# Altium schematic sheet MMB-2013-007a IO PS.SchDoc("MMB-2013-007a IO PS")
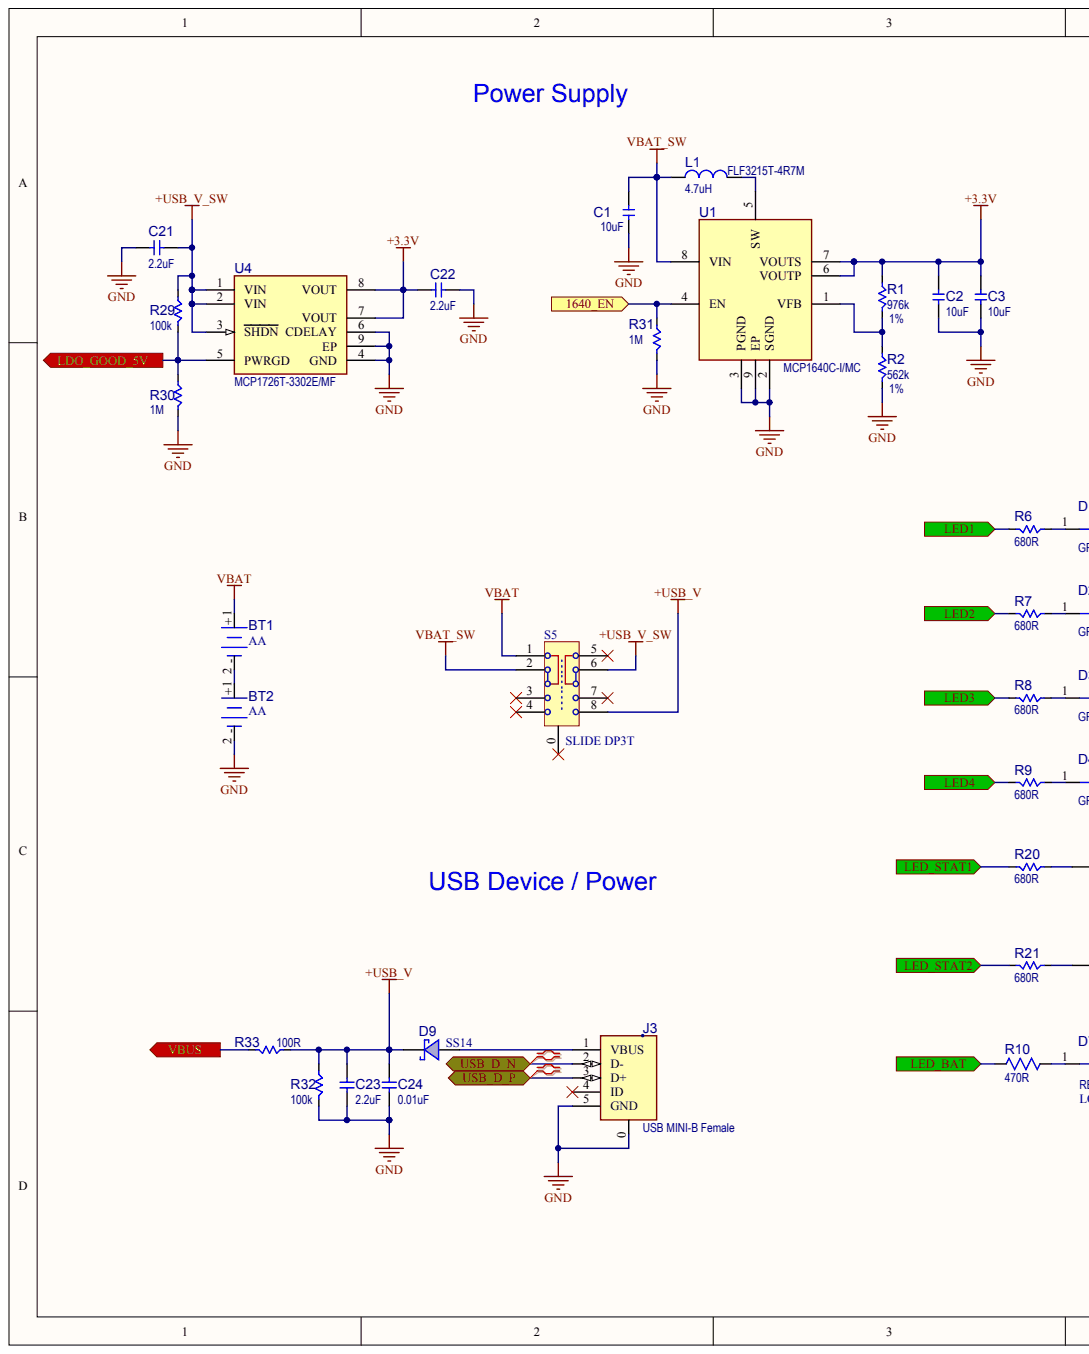
[diagram p0: MMB-2013-007a IO PS.SchDoc, left]
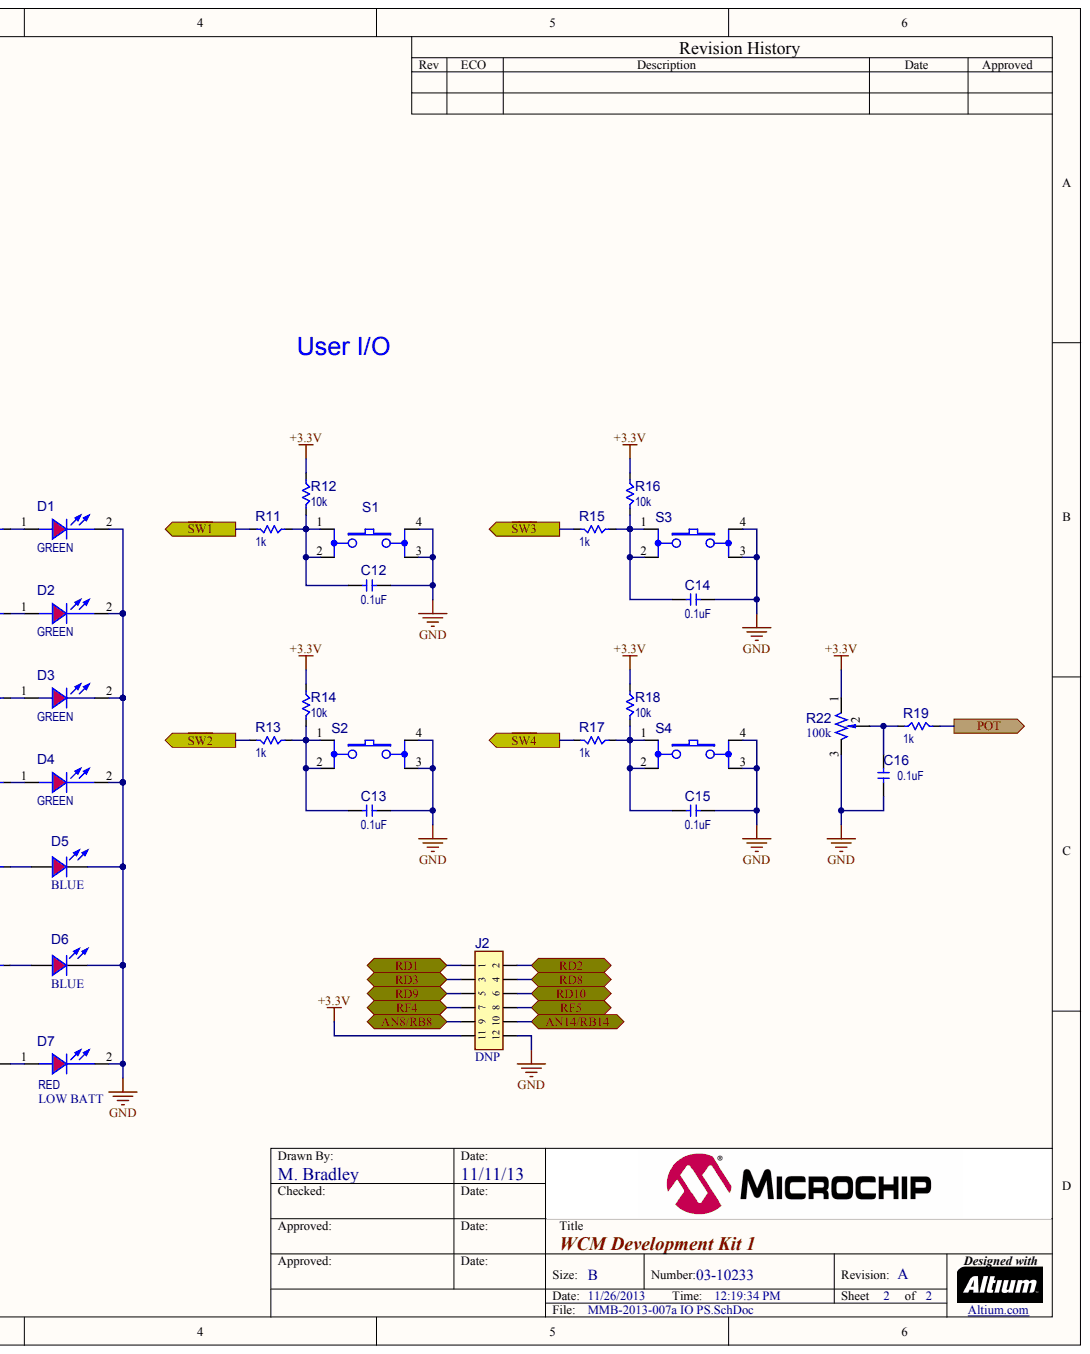
[diagram p1: MMB-2013-007a IO PS.SchDoc, right]

## Parts
BT1  value AA  (hidden comment: Battery 2 Pin)
BT2  value AA  (hidden comment: Battery 2 Pin)
C1  value 10uF  (hidden comment: Capacitor NP)
C2  value 10uF  (hidden comment: Capacitor NP)
C3  value 10uF  (hidden comment: Capacitor NP)
C12  value 0.1uF  (hidden comment: Capacitor NP)
C13  value 0.1uF  (hidden comment: Capacitor NP)
C14  value 0.1uF  (hidden comment: Capacitor NP)
C15  value 0.1uF  (hidden comment: Capacitor NP)
C16  value 0.1uF  (hidden comment: Capacitor NP)
C21  value 2.2uF  (hidden comment: Capacitor NP)
C22  value 2.2uF  (hidden comment: Capacitor NP)
C23  value 2.2uF  (hidden comment: Capacitor NP)
C24  value 0.01uF  (hidden comment: Capacitor NP)
D1  value GREEN  (hidden comment: LED)
D2  value GREEN  (hidden comment: LED)
D3  value GREEN  (hidden comment: LED)
D4  value GREEN  (hidden comment: LED)
D5  value BLUE  (hidden comment: LED)
D6  value BLUE  (hidden comment: LED)
D7  LOW BATT  value RED
D9  value SS14  (hidden comment: Diode Schottky)
J2  DNP
J3  value USB MINI-B Female  Type: USB  (hidden comment: CON USB-B)
L1  value 4.7uH  Manufacturer Part Number 1: FLF3215T-4R7M  (hidden comment: Inductor)
R1  value 976k  Tolerance: 1%  (hidden comment: Resistor)
R2  value 562k  Tolerance: 1%  (hidden comment: Resistor)
R6  value 680R  (hidden comment: Resistor)
R7  value 680R  (hidden comment: Resistor)
R8  value 680R  (hidden comment: Resistor)
R9  value 680R  (hidden comment: Resistor)
R10  value 470R  (hidden comment: Resistor)
R11  value 1k  (hidden comment: Resistor)
R12  value 10k  (hidden comment: Resistor)
R13  value 1k  (hidden comment: Resistor)
R14  value 10k  (hidden comment: Resistor)
R15  value 1k  (hidden comment: Resistor)
R16  value 10k  (hidden comment: Resistor)
R17  value 1k  (hidden comment: Resistor)
R18  value 10k  (hidden comment: Resistor)
R19  value 1k  (hidden comment: Resistor)
R20  value 680R  (hidden comment: Resistor)
R21  value 680R  (hidden comment: Resistor)
R22  value 100k  (hidden comment: Resistor Var)
R29  value 100k  (hidden comment: Resistor)
R30  value 1M  (hidden comment: Resistor)
R31  value 1M  (hidden comment: Resistor)
R32  value 100k  (hidden comment: Resistor)
R33  value 100R  (hidden comment: Resistor)
S1  Switch 4 Pin  (value not drawn)
S2  Switch 4 Pin  (value not drawn)
S3  Switch 4 Pin  (value not drawn)
S4  Switch 4 Pin  (value not drawn)
S5  value SLIDE DP3T  (hidden comment: Switch 8 Pin DP3T)
U1  Manufacturer Part Number 1: MCP1640C-I/MC  (hidden comment: MCP1640C DFN-8)
U4  Manufacturer Part Number 1: MCP1726T-3302E/MF  (hidden comment: MCP1726 FIX DFN-8)

## Ports
1640_EN, AN8/RB8, AN14/RB14, LDO_GOOD_5V, LED1, LED2, LED3, LED4, LED_BAT, LED_STAT1, LED_STAT2, POT, RD1, RD2, RD3, RD8, RD9, RD10, RF4, RF5, USB_D_N, USB_D_P, VBUS, ~{SW1}, ~{SW2}, ~{SW3}, ~{SW4}

## Nets
+3.3V: C2.2 C3.2 C22.2 J2.11 R1.2 R12.2 R14.2 R16.2 R18.2 R22.1 U1.6(VOUTP) U1.7(VOUTS) U4.7(VOUT) U4.8(VOUT)
+USB_V: C23.2 C24.2 D9.2 R32.2 R33.2 S5.8
+USB_V_SW: C21.1 R29.2 S5.6 U4.1(VIN) U4.2(VIN) U4.3(SHDN)
GND: BT2.2 C1.1 C2.1 C3.1 C12.1 C13.1 C14.1 C15.1 C16.1 C21.2 C22.1 C23.1 C24.1 D1.2 D2.2 D3.2 D4.2 D5.2 D6.2 D7.2 J2.12 J3.0 J3.5(GND) R2.1 R22.3 R30.1 R31.1 R32.1 S1.3 S1.4 S2.3 S2.4 S3.3 S3.4 S4.3 S4.4 U1.2(SGND) U1.3(PGND) U1.9(EP) U4.4(GND) U4.6(CDELAY) U4.9(EP)
VBAT: BT1.1 S5.1
VBAT_SW: C1.2 L1.1 S5.2 U1.8(VIN)
1640_EN: R31.2 U1.4(EN) ; port 1640_EN
AN8/RB8: J2.9 ; port AN8/RB8
AN14/RB14: J2.10 ; port AN14/RB14
LDO_GOOD_5V: R29.1 R30.2 U4.5(PWRGD) ; port LDO_GOOD_5V
LED1: R6.1 ; port LED1
LED2: R7.1 ; port LED2
LED3: R8.1 ; port LED3
LED4: R9.1 ; port LED4
LED_BAT: R10.1 ; port LED_BAT
LED_STAT1: R20.1 ; port LED_STAT1
LED_STAT2: R21.1 ; port LED_STAT2
POT: R19.1 ; port POT
RD1: J2.1 ; port RD1
RD2: J2.2 ; port RD2
RD3: J2.3 ; port RD3
RD8: J2.4 ; port RD8
RD9: J2.5 ; port RD9
RD10: J2.6 ; port RD10
RF4: J2.7 ; port RF4
RF5: J2.8 ; port RF5
~{SW1}: R11.1 ; port ~{SW1}
~{SW2}: R13.1 ; port ~{SW2}
~{SW3}: R15.1 ; port ~{SW3}
~{SW4}: R17.1 ; port ~{SW4}
USB_D_N: J3.2(D-) ; port USB_D_N
USB_D_P: J3.3(D+) ; port USB_D_P
VBUS: R33.1 ; port VBUS
NetBT1_2: BT1.2 BT2.1
NetC12_2: C12.2 R11.2 R12.1 S1.1 S1.2
NetC13_2: C13.2 R13.2 R14.1 S2.1 S2.2
NetC14_2: C14.2 R15.2 R16.1 S3.1 S3.2
NetC15_2: C15.2 R17.2 R18.1 S4.1 S4.2
NetC16_2: C16.2 R19.2 R22.2
NetD1_1: D1.1 R6.2
NetD2_1: D2.1 R7.2
NetD3_1: D3.1 R8.2
NetD4_1: D4.1 R9.2
NetD5_1: D5.1 R20.2
NetD6_1: D6.1 R21.2
NetD7_1: D7.1 R10.2
NetD9_1: D9.1 J3.1(VBUS)
NetL1_2: L1.2 U1.5(SW)
NetR1_1: R1.1 R2.2 U1.1(VFB)

Not connected: J3 4(ID); S5 0 3 4 5 7

## Library data of the parts
BT1 BT2 = (no symbol name): Manufacturer 1: Keystone Electronics; Manufacturer Part Number 1: 2460; MCHP-ID: BAT1011; Description_: BATT HOLDER 2 TH AA; Owner: Tad Ahlvers; Populated: YES; Revision: A; Status: Design; ComponentLink1URL: https://chn-vm-apps.microchip.com/mchprepo/parts/Datasheets/2460.pdf; ComponentLink1Description: Datasheet; Type: Holder; Category: Battery; Supplier 1: Digi-Key; Supplier Part Number 1: 2460K-ND; Quantity Override: 1
C1-C3 = (no symbol name): Manufacturer 1: Taiyo Yuden; Manufacturer Part Number 1: JMK212BJ106MG-T; MCHP-ID: CAP0280; Voltage: 6.3V; Description_: CAP CER 10uF 6.3V 20% X5R SMD 0805; Tolerance: 20%; Temperature Coeffcient: X5R; Type: Ceramic; Populated: Yes; Package: 0805; Revision: 1; Status: Production; ComponentLink1URL: https://chn-vm-apps.microchip.com/mchprepo/parts/Datasheets/mlcc01_e.pdf; ComponentLink1Description: Datasheet; Operating Temperature: -55C to +85C; Category: Capacitor; Manufacturer 2: Murata; Manufacturer Part Number 2: GRM21BR60J106ME19L; Supplier 1: Digi-Key; Supplier Part Number 1: 587-1943-1-ND; Supplier 2: Digi-Key; Supplier Part Number 2: 490-1718-1-ND; Quantity Override: 1
C12-C16 = (no symbol name): Manufacturer 1: TDK; Manufacturer Part Number 1: C1608X7R1C104K; MCHP-ID: CAP1166; Voltage: 16V; Description_: CAP CER 0.1uF 16V 10% X7R 0603; Tolerance: 10%; Temperature Coeffcient: X7R; Type: Ceramic; Owner: Bogdan P (M91398); Populated: Yes; Package: 0603; Revision: 0; Status: Production; ComponentLink1URL: https://chn-vm-apps.microchip.com/mchprepo/parts/Datasheets/general_B11.pdf; ComponentLink1Description: Datasheet; Operating Temperature: -55C to +125C; Category: Capacitor; Supplier 1: Digi-Key; Supplier Part Number 1: 445-1317-1-ND; Quantity Override: 1
C21-C23 = (no symbol name): Manufacturer 1: TDK; Manufacturer Part Number 1: C1608X5R1C225K; MCHP-ID: CAP0597; Voltage: 16V; Description_: CAP CER 2.2uF 16V 10% X5R SMD 0603; Tolerance: 10%; Temperature Coeffcient: X5R; Type: Ceramic; Populated: Yes; Package: 0603; Revision: 2; Status: Production; ComponentLink1URL: https://chn-vm-apps.microchip.com/mchprepo/parts/Datasheets/general_B11.pdf; ComponentLink1Description: Datasheet; Operating Temperature: -55C to +85C; Category: Capacitor; Supplier 1: Digi-Key; Supplier Part Number 1: 445-5157-1-ND; Quantity Override: 1
C24 = (no symbol name): Manufacturer 1: Panasonic; Manufacturer Part Number 1: ECJ-1VB1C103K; MCHP-ID: CAP0451; Voltage: 16V; Description_: CAP CER 0.01uF 16V 10% X7R SMD 0603; Tolerance: 10%; Temperature Coeffcient: X7R; Type: Ceramic; Populated: Yes; Package: 0603; Revision: 1; Status: Production; ComponentLink1URL: https://chn-vm-apps.microchip.com/mchprepo/parts/Datasheets/L0005E.pdf; ComponentLink1Description: Datasheet; Operating Temperature: -55C to +125C; Category: Capacitor; Manufacturer 2: Murata; Manufacturer Part Number 2: GRM188R71C103KA01D; Manufacturer 3: Johanson Dielectrics; Manufacturer Part Number 3: 160R14W103KV4T; Supplier 1: Digi-Key; Supplier Part Number 1: PCC1750CT-ND; Supplier 2: Digi-Key; Supplier Part Number 2: 490-1525-1-ND; Supplier 3: Digi-Key; Supplier Part Number 3: 709-1152-2-ND; Quantity Override: 1
D1-D4 = (no symbol name): Manufacturer 1: Lumex Opto/Components Inc; Manufacturer Part Number 1: SML-LXT0805GW-TR; MCHP-ID: LED1022; Description_: DIO LED GREEN 2V 30mA 0805; Type: LED Single; Forward Current: 30mA; Power: 50mW; Reverse Current: 100uA; Reverse Voltage: 5V; Owner: Stephen Humberd; Populated: YES; Revision: 0; Status: Production; Package: 0805; ComponentLink1URL: https://chn-vm-apps.microchip.com/mchprepo/parts/Datasheets/SML-LXT0805GW-TR.pdf; ComponentLink1Description: Datasheet; Operating Temperature: -25C to +80C; Category: Diode; Supplier 1: Digi-Key; Supplier Part Number 1: 67-1553-1-ND; Quantity Override: 1
D5 D6 = (no symbol name): Manufacturer 1: Kingbright Company LLC; Manufacturer Part Number 1: APT2012QBC/D; MCHP-ID: DIODE1080; Description_: DIO LED BLUE 3.3V 20mA 100mcd Clear SMD 0805; Type: LED Single; Forward Current: 20mA; Power: 120mW; Reverse Current: 50uA; Reverse Voltage: 5V; Owner: Tad Ahlvers; Populated: YES; Revision: A; Status: Design; Package: 0805; ComponentLink1URL: https://chn-vm-apps.microchip.com/mchprepo/parts/Datasheets/APT2012QBC-D.pdf; ComponentLink1Description: Datasheet; Operating Temperature: -40C to +85C; Category: Diode; Supplier 1: Digi-Key; Supplier Part Number 1: 754-1437-1-ND; Quantity Override: 1
D7 = (no symbol name): Manufacturer 1: Lumex; Manufacturer Part Number 1: SML-LXT0805IW-TR; MCHP-ID: LED1020; Description_: DIO LED RED 2V 30mA 0805; Type: LED Single; Forward Current: 30mA; Power: 50mW; Reverse Current: 100uA; Reverse Voltage: 5V; Owner: Stephen Humberd; Populated: YES; Revision: A; Status: Design; Package: 0805; ComponentLink1URL: https://chn-vm-apps.microchip.com/mchprepo/parts/Datasheets/SML-LXT0805IW-TR.pdf; ComponentLink1Description: Datasheet; Operating Temperature: -25C to +80C; Category: Diode; Supplier 1: Digi-Key; Supplier Part Number 1: 67-1552-1-ND; Quantity Override: 1
D9 = (no symbol name): Manufacturer 1: Diodes Incorporated; Manufacturer Part Number 1: B140-13; MCHP-ID: DIODE0150; Description_: DIO SCTKY SS14 500mV 1A 40V DO-214AC_SMA; Type: Schottky Single; Forward Current: 1A; Reverse Current: 200uA; Reverse Voltage: 40V; Populated: Yes; Revision: 2; Status: Production; Package: DO-214AC_SMA; ComponentLink1URL: https://chn-vm-apps.microchip.com/mchprepo/parts/Datasheets/ss12.pdf; ComponentLink1Description: Datasheet; Operating Temperature: -65C to +125C; Category: Diode; Manufacturer 2: Diodes Incorporated; Manufacturer Part Number 2: B140-13-F; Manufacturer 3: Vishay; Manufacturer Part Number 3: SS14-E3/61T; Supplier 1: Digi-Key; Supplier Part Number 1: B140DICT-ND; Supplier 2: Diodes Incorporated; Supplier Part Number 2: B140-13-F; Supplier 3: Digi-Key; Supplier Part Number 3: SS14-E3/61TGICT-ND; Quantity Override: 1
J2 = (no symbol name): Manufacturer 1: Samtec; Manufacturer Part Number 1: TSW-106-07-G-D; MCHP-ID: CON0043; Value: HDR-2.54 Male 2x6; Description_: CON HDR-2.54 Male 2x6 Gold 5.84MH TH VERT; Current: 3A; Populated: No; Revision: 1; Status: Production; ComponentLink1URL: https://chn-vm-apps.microchip.com/mchprepo/parts/Datasheets/97_Page78-79_HEADER_.100_2.54mm_MALE_BREAKAWAY.pdf; ComponentLink1Description: Datasheet; Type: Header 2.54mm; Category: Connector; Manufacturer 2: Samtec; Manufacturer Part Number 2: TSW-106-07-S-D; Manufacturer 3: Sullins; Manufacturer Part Number 3: PBC06DAAN; Supplier 1: Digi-Key; Supplier Part Number 1: SAM1028-06-ND; Supplier 2: Digi-Key; Supplier Part Number 2: SAM1032-06-ND; Supplier 3: Digi-Key; Supplier Part Number 3: S2011E-06-ND; Quantity Override: 1
J3 = (no symbol name): Manufacturer 1: Hirose; Manufacturer Part Number 1: UX60-MB-5ST; MCHP-ID: CON0276; Description_: CON USB MINI-B Female SMD R/A; Populated: Yes; Revision: 2; Status: Production; ComponentLink1URL: https://chn-vm-apps.microchip.com/mchprepo/parts/Datasheets/e24000019.pdf; ComponentLink1Description: Datasheet; Category: Connector; Manufacturer 2: Oupiin; Manufacturer Part Number 2: 8969-B05C00DBU; Supplier 1: Digi-Key; Supplier Part Number 1: H2959TR-ND; Supplier 2: Digi-Key; Supplier Part Number 2: H2959CT-ND; Supplier 3: Oupiin; Supplier Part Number 3: 8969-B05C00DBU; Quantity Override: 1
L1 = (no symbol name): Manufacturer 1: TDK; MCHP-ID: IND0071; Description_: INDUCTOR 4.7uH 1A 20% SMD 1210; DCR: 0.09R; Saturation Current: 1A; Test Frequency: 1MHz; Populated: Yes; Revision: 1; Status: Production; ComponentLink1URL: https://chn-vm-apps.microchip.com/mchprepo/parts/Datasheets/e531_flf3215.pdf; ComponentLink1Description: Datasheet; Operating Temperature: -40C to +125C; Package: 1210; Type: Inductor; Category: Inductor; Supplier 1: Digi-Key; Supplier Part Number 1: 445-4846-2-ND; Quantity Override: 1
R1 = (no symbol name): Manufacturer 1: Panasonic; Manufacturer Part Number 1: ERJ-3EKF9763V; MCHP-ID: RSMT0718; Description_: RES TKF 976k 1% 1/10W SMD 0603; Voltage: 75V; Temperature Coeffcient: 100ppm/C; Power: 1/10W; Populated: Yes; Package: 0603; Revision: 1; Status: Production; ComponentLink1URL: https://chn-vm-apps.microchip.com/mchprepo/parts/Datasheets/AOA0000CE2.pdf; ComponentLink1Description: Datasheet; Operating Temperature: -55C to +155C; Category: Resistor; Type: RES SMD; Composition: TKF; Manufacturer 2: Yageo; Manufacturer Part Number 2: RC0603FR-07976KL; Supplier 1: Digi-Key; Supplier Part Number 1: P976KHCT-ND; Supplier 2: Digi-Key; Supplier Part Number 2: 311-976KHRTR-ND; Quantity Override: 1
R2 = (no symbol name): Manufacturer 1: Panasonic; Manufacturer Part Number 1: ERJ-3EKF5623V; MCHP-ID: RSMT0719; Description_: RES TKF 562k 1% 1/10W SMD 0603; Voltage: 75V; Temperature Coeffcient: 100ppm/C; Power: 1/10W; Populated: Yes; Package: 0603; Revision: 1; Status: Production; ComponentLink1URL: https://chn-vm-apps.microchip.com/mchprepo/parts/Datasheets/AOA0000CE2.pdf; ComponentLink1Description: Datasheet; Operating Temperature: -55C to +155C; Category: Resistor; Type: RES SMD; Composition: TKF; Manufacturer 2: Vishay; Manufacturer Part Number 2: CRCW0603562KFKEA; Manufacturer 3: Yageo; Manufacturer Part Number 3: RC0603FR-07562KL; Supplier 1: Digi-Key; Supplier Part Number 1: 562KHCT-ND; Supplier 2: Digi-Key; Supplier Part Number 2: 541-562KHCT-ND; Supplier 3: Digi-Key; Supplier Part Number 3: 311-562KHRTR-ND; Quantity Override: 1
R6-R9 R20 R21 = (no symbol name): Manufacturer 1: Panasonic; Manufacturer Part Number 1: ERJ-3GSYJ681V; MCHP-ID: RSMT0122; Tolerance: 5%; Description_: RES TKF 680R 5% 1/10W SMD 0603; Voltage: 75V; Temperature Coeffcient: 200ppm/C; Power: 1/10W; Populated: Yes; Package: 0603; Revision: 1; Status: Production; ComponentLink1URL: https://chn-vm-apps.microchip.com/mchprepo/parts/Datasheets/AOA0000CE1.pdf; ComponentLink1Description: Datasheet; Operating Temperature: -55C to +155C; Category: Resistor; Type: RES SMD; Composition: TKF; Manufacturer 2: Panasonic; Manufacturer Part Number 2: ERJ-3GEYJ681V; Supplier 1: Digi-Key; Supplier Part Number 1: P680GTR-ND; Supplier 2: Digi-Key; Supplier Part Number 2: P680GCT-ND; Quantity Override: 1
R10 = (no symbol name): Manufacturer 1: NIC Components; Manufacturer Part Number 1: NRC06J471TRF; MCHP-ID: RSMT0098; Tolerance: 5%; Description_: RES TKF 470R 5% 1/10W SMD 0603; Temperature Coeffcient: 200ppm/C; Power: 1/10W; Populated: Yes; Package: 0603; Revision: 1; Status: Production; ComponentLink1URL: https://chn-vm-apps.microchip.com/mchprepo/parts/Datasheets/nrc.pdf; ComponentLink1Description: Datasheet; Operating Temperature: -55C to +155C; Category: Resistor; Type: RES SMD; Composition: TKF; Manufacturer 2: Panasonic; Manufacturer Part Number 2: ERJ-3GEYJ471V; Manufacturer 3: Stackpole Electronics Inc; Manufacturer Part Number 3: RMCF0603JT470R; Supplier 1: NIC Components; Supplier Part Number 1: NRC06J471TRF; Supplier 2: Digi-Key; Supplier Part Number 2: P470GTR-ND; Supplier 3: Digi-Key; Supplier Part Number 3: RMCF0603JT470RCT-ND; Quantity Override: 1
R11 R13 R15 R17 R19 = (no symbol name): Manufacturer 1: Panasonic; Manufacturer Part Number 1: ERJ-3GEYJ102V; MCHP-ID: RSMT0047; Tolerance: 5%; Description_: RES TKF 1k 5% 1/10W SMD 0603; Voltage: 75V; Temperature Coeffcient: 200ppm/C; Power: 1/10W; Populated: Yes; Package: 0603; Revision: 1; Status: Production; ComponentLink1URL: https://chn-vm-apps.microchip.com/mchprepo/parts/Datasheets/AOA0000CE1.pdf; ComponentLink1Description: Datasheet; Operating Temperature: -55C to +155C; Category: Resistor; Type: RES SMD; Composition: TKF; Manufacturer 2: KOA Speer; Manufacturer Part Number 2: RK73B1JTTD102J; Manufacturer 3: Panasonic; Manufacturer Part Number 3: ERJ-3GSYJ102V; Manufacturer 4: ROHM; Manufacturer Part Number 4: MCR03EZHJ1K; Manufacturer 5: ROHM; Manufacturer Part Number 5: MCR03FZHJ1001; Manufacturer 6: Stackpole Electronics Inc; Manufacturer Part Number 6: RMCF 1/16 1K 5% R; Manufacturer 7: Yageo; Manufacturer Part Number 7: RC0603JR-071KL; Supplier 1: Digi-Key; Supplier Part Number 1: P1.0KGCT-ND; Supplier 2: Digi-Key; Supplier Part Number 2: P1.0KGTR-ND; Supplier 3: KOA Speer; Supplier Part Number 3: RK73B1JTTD102J; Supplier 4: ROHM; Supplier Part Number 4: MCR03EZHJ1K; Supplier 5: ROHM; Supplier Part Number 5: MCR03FZHJ1001; Supplier 6: Stackpole Electronics Inc; Supplier Part Number 6: RMCF 1/16 1K 5% R; Supplier 7: Digi-Key; Supplier Part Number 7: 311-1.0KGRTR-ND; Quantity Override: 1
R12 R14 R16 R18 = (no symbol name): Manufacturer 1: Panasonic; Manufacturer Part Number 1: ERJ-3GEYJ103V; MCHP-ID: RES0191; Tolerance: 5%; Description_: RES TKF 10k 5% 1/10W SMD 0603; Voltage: 75V; Temperature Coeffcient: 200ppm/C; Power: 1/10W; Populated: Yes; Package: 0603; Revision: 2; Status: Production; ComponentLink1URL: https://chn-vm-apps.microchip.com/mchprepo/parts/Datasheets/AOA0000CE1.pdf; ComponentLink1Description: Datasheet; Operating Temperature: -55C to +155C; Category: Resistor; Type: RES SMD; Composition: TKF; Manufacturer 2: NIC Components; Manufacturer Part Number 2: NRC06J103TRF; Manufacturer 3: Yageo; Manufacturer Part Number 3: RC0603JR-0710KL; Supplier 1: Digi-Key; Supplier Part Number 1: P10KGCT-ND; Supplier 2: Digi-Key; Supplier Part Number 2: P10KGTR-ND; Supplier 3: NIC Components; Supplier Part Number 3: NRC06J103TRF; Supplier 4: Digi-Key; Supplier Part Number 4: 311-10KGRTR-ND; Quantity Override: 1
R22 = (no symbol name): Manufacturer 1: Bourns; Manufacturer Part Number 1: 3352T-1-104LF; MCHP-ID: RPOT0037; Tolerance: 20%; Description_: RES TRIMMER Cermet 100k 20% 500mW TH 91A; Temperature Coeffcient: 100ppm/C; Circuit Type: Single Turn; Power: 500mW; Populated: YES; Package: 91A; Revision: 1; Status: Design; ComponentLink1URL: https://chn-vm-apps.microchip.com/mchprepo/parts/Datasheets/91.pdf; ComponentLink1Description: Datasheet; Operating Temperature: -55C to +125C; Category: Resistor; Type: Trimmer; Composition: Cermet; Manufacturer 2: BI Technologies; Manufacturer Part Number 2: 91AR100KLF; Supplier 1: Digi-Key; Supplier Part Number 1: 3352T-104LF-ND; Supplier 2: Mouser; Supplier Part Number 2: 858-91AR100KLF; Quantity Override: 1
R29 R32 = (no symbol name): Manufacturer 1: Panasonic; Manufacturer Part Number 1: ERJ-3GEYJ104V; MCHP-ID: RSMT0026; Tolerance: 5%; Description_: RES TKF 100k 5% 1/10W SMD 0603; Voltage: 75V; Temperature Coeffcient: 200ppm/C; Power: 1/10W; Populated: Yes; Package: 0603; Revision: 2; Status: Production; ComponentLink1URL: https://chn-vm-apps.microchip.com/mchprepo/parts/Datasheets/AOA0000CE1.pdf; ComponentLink1Description: Datasheet; Operating Temperature: -55C to +155C; Category: Resistor; Type: RES SMD; Composition: TKF; Manufacturer 2: KOA Speer; Manufacturer Part Number 2: RK73B1JTTD104J; Manufacturer 3: Panasonic; Manufacturer Part Number 3: ERJ-3GSYJ104V; Manufacturer 4: ROHM; Manufacturer Part Number 4: MCR03EZPJ104; Manufacturer 5: Stackpole Electronics Inc; Manufacturer Part Number 5: RMCF 1/16 100K 5% R; Supplier 1: Digi-Key; Supplier Part Number 1: P100KGTR-ND; Supplier 2: KOA Speer; Supplier Part Number 2: RK73B1JTTD104J; Supplier 3: Digi-Key; Supplier Part Number 3: RHM100KGTR-ND; Supplier 4: Stackpole Electronics Inc; Supplier Part Number 4: RMCF 1/16 100K 5% R; Quantity Override: 1
R30 R31 = (no symbol name): Manufacturer 1: Yageo; Manufacturer Part Number 1: 9C06031A1004JLHFT; MCHP-ID: RSMT0187; Tolerance: 5%; Description_: RES TKF 1M 5% 1/10W SMD 0603; Voltage: 50V; Temperature Coeffcient: 100ppm/C; Power: 1/10W; Populated: Yes; Package: 0603; Revision: 1; Status: Production; ComponentLink1URL: https://chn-vm-apps.microchip.com/mchprepo/parts/Datasheets/PYu-RC0603_51_RoHS_L_4.pdf; ComponentLink1Description: Datasheet; Operating Temperature: -55C to +155C; Category: Resistor; Type: RES SMD; Composition: TKF; Manufacturer 2: KOA Speer; Manufacturer Part Number 2: RK73B1JTTD105J; Manufacturer 3: Panasonic; Manufacturer Part Number 3: ERJ-3GEYJ105V; Manufacturer 4: Stackpole Electronics Inc; Manufacturer Part Number 4: RMCF1/161M5%R; Manufacturer 5: Yageo; Manufacturer Part Number 5: RC0603JR-071ML; Manufacturer 6: Yageo; Manufacturer Part Number 6: 9C06031A1004JLPFT; Manufacturer 7: Stackpole Electronics Inc; Manufacturer Part Number 7: RMCF0603JT1M00; Supplier 1: Yageo; Supplier Part Number 1: 9C06031A1004JLHFT; Supplier 2: KOA Speer; Supplier Part Number 2: RK73B1JTTD105J; Supplier 3: Digi-Key; Supplier Part Number 3: P1.0MGTR-ND; Supplier 4: Stackpole Electronics Inc; Supplier Part Number 4: RMCF1/161M5%R; Supplier 5: Yageo; Supplier Part Number 5: RC0603JR-071ML; Supplier 6: Yageo; Supplier Part Number 6: 9C06031A1004JLPFT; Supplier 7: Digi-Key; Supplier Part Number 7: RMCF0603JT1M00CT-ND; Quantity Override: 1
R33 = (no symbol name): Manufacturer 1: ROHM; Manufacturer Part Number 1: MCR03EZPJ101; MCHP-ID: RES0193; Tolerance: 5%; Description_: RES TKF 100R 5% 1/10W SMD 0603; Voltage: 50V; Temperature Coeffcient: 100ppm/C; Power: 1/10W; Populated: Yes; Package: 0603; Revision: 1; Status: Production; ComponentLink1URL: https://chn-vm-apps.microchip.com/mchprepo/parts/Datasheets/mcr_a.pdf; ComponentLink1Description: Datasheet; Operating Temperature: -55C to +155C; Category: Resistor; Type: RES SMD; Composition: TKF; Supplier 1: Digi-Key; Supplier Part Number 1: RHM100GCT-ND; Quantity Override: 1
S1-S4 = (no symbol name): Manufacturer 1: E-Switch; Manufacturer Part Number 1: TL3301AF160QG; MCHP-ID: SWITCH0095; Value: SPST; Description_: SWITCH SPST 12V 50mA TL3301AF160QG SMD; Voltage: 12V; Current: 50mA; Populated: Yes; Revision: 1; Status: Production; ComponentLink1URL: https://chn-vm-apps.microchip.com/mchprepo/parts/Datasheets/TL3301.pdf; ComponentLink1Description: Datasheet; Operating Temperature: -20C to +70C; Category: Switch; Supplier 1: Digi-Key; Supplier Part Number 1: EG2526CT-ND; Quantity Override: 1
S5 = (no symbol name): Manufacturer 1: C&K Components; Manufacturer Part Number 1: OS203012MU5QP1; MCHP-ID: SWITCH1025; Description_: SWITCH SLIDE DP3T 30V 100mA OS203012MU5QP1 TH; Voltage: 30V; Current: 100mA; Populated: YES; Revision: A; Status: Design; ComponentLink1URL: https://chn-vm-apps.microchip.com/mchprepo/parts/Datasheets/OS_11mar13-1.pdf; ComponentLink1Description: Datasheet; Category: Switch; Type: Slide; Supplier 1: Digi-Key; Supplier Part Number 1: CKN9554-ND; Quantity Override: 1
U1 = (no symbol name): Manufacturer 1: Microchip; MCHP-ID: MIC2459; Value: MCP1640/2V to 5.5V; Description_: MCHP ANALOG SWITCHER Boost 2V to 5.5V MCP1640CT-I/MC DFN-8; MAPS Description: PFM/PWM Enabled, Input to Output Bypass; MPC: NBAB17B3XC00; LeadContent: Pb-FREE; PackingMedia: TUBE; Stage: REL; LeadCount: 8; PackageWidth: 2x3x0.9mm; ForceMinOrderQtyMult: False; MinOrderQty: 5; TempRange: -40C to +85C; tblDeviceFamilyID: 2382; UVLO: No; Topology: Boost Regulator; SingleDual: Single; SHDN: Yes; InputVoltageRangeMin: 0.65; InputVoltageRangeMax: 5.5; VoutMin: 2; Accuracy: 3; QuiescentCurrent: 19; ControlPWM: PWM/PFM; SwitchingFrequency: 500; OutputCapType: AL / Tant / CER; MaxIOUT: 350; Type: Switcher; Status: Production; Revision: 0; Category: MCHP-Analog; ComponentLink1URL: http://www.microchip.com/stellent/idcplg?IdcService=SS_GET_PAGE&nodeId=1335&dDocName=en547080; ComponentLink1Description: Datasheet; Manufacturer 2: Microchip; Manufacturer Part Number 2: MCP1640CT-I/MC; Supplier 1: Microchip; Supplier Part Number 1: MCP1640C-I/MC; Supplier 2: Microchip; Supplier Part Number 2: MCP1640CT-I/MC; IntroductionDate: 2010-02-18; PackageType: DFN; Technology: Inductive Switcher; ResetOutput: No; VoutMax: 5.5; Adjustable: Yes; ExternalSync: No; Populated: Yes; Quantity Override: 1
U4 = (no symbol name): Manufacturer 1: Microchip; MCHP-ID: MIC2613; Value: MCP1726/3.3V; Description_: MCHP ANALOG LDO 3.3V MCP1726T-3302E/MF DFN-8; MAPS Description: 1A Low Voltage Low lq LDO; MPC: GBAM1YA7XA33; LeadContent: Pb-FREE; PackingMedia: T/R; Stage: REL; LeadCount: 8; PackageWidth: 3x3x0.9mm; ForceMinOrderQtyMult: True; MinOrderQty: 50; TempRange: -40C to +125C; tblDeviceFamilyID: 1153; Comments: Fixed or adjustable output version, Power good output, shutdown input, adjustable delay for PGOOD output.; SingleDual: Single; SHDN: Yes; ResetOutput: No; VoutMin: 0.8; VoutMax: 5; OutputCurrent: 1000; Accuracy: 0.5; OutputCapType: CER/AL/TANT; VOUTtyp: 0.8, 1.2, 1.8, 2.5, 3.3, 5.0; TypicalActiveCurrent: 130; Dropoutvoltage: 500; MinInputVoltage: 2.3; MaxInputVoltage: 6; Type: LDO; Status: Production; Revision: 0; Category: MCHP-Analog; ComponentLink1URL: http://www.microchip.com/stellent/idcplg?IdcService=SS_GET_PAGE&nodeId=1335&dDocName=en021857; ComponentLink1Description: Datasheet; Manufacturer 2: Microchip; Manufacturer Part Number 2: MCP1726-3302E/MF; Supplier 1: Microchip; Supplier Part Number 1: MCP1726T-3302E/MF; Supplier 2: Microchip; Supplier Part Number 2: MCP1726-3302E/MF; IntroductionDate: 2005-02-22; PackageType: DFN; Adjustable: Yes; Populated: Yes; Quantity Override: 1
